# Altium schematic sheet TriangleGenerator.SchDoc(TriangleGenerator)
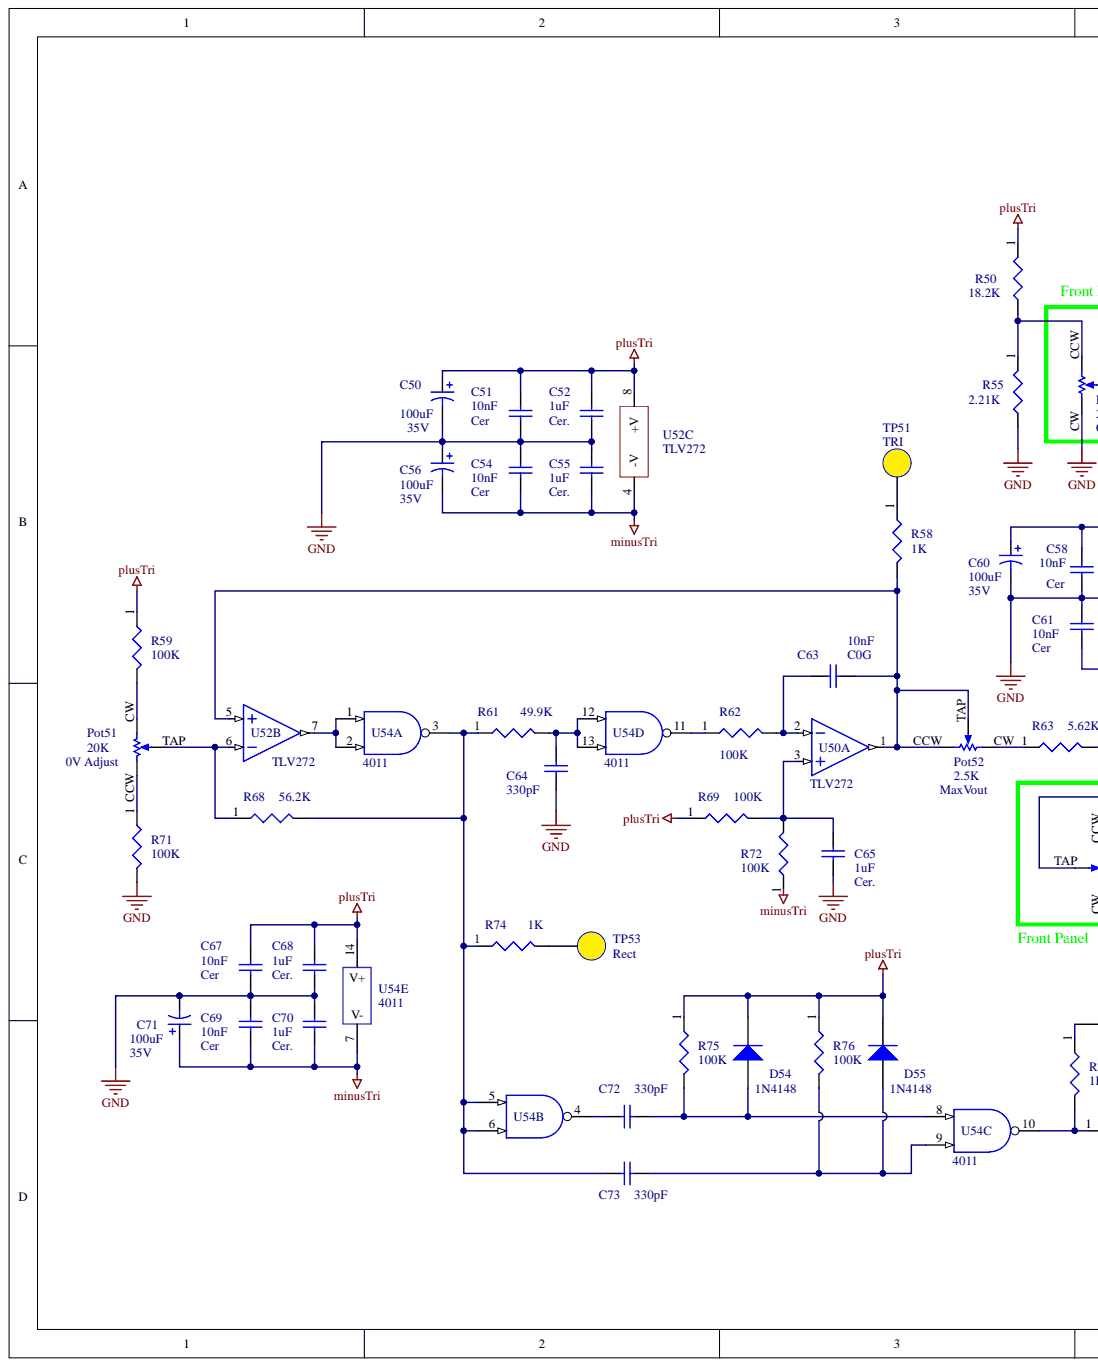
[diagram p0: TriangleGenerator.SchDoc, left]
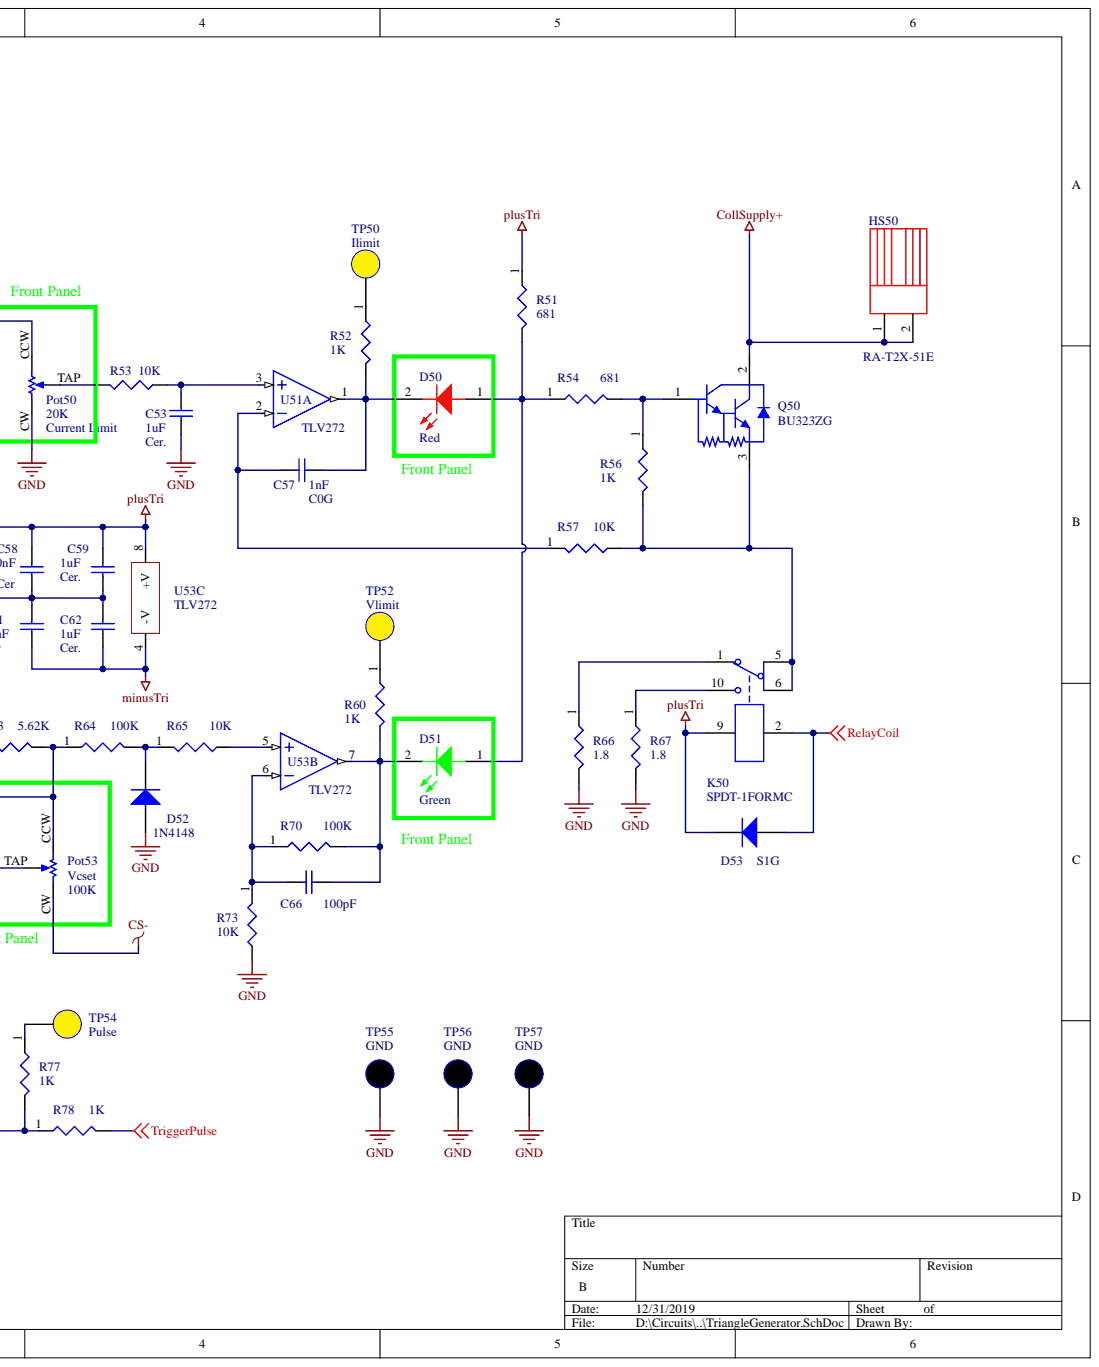
[diagram p1: TriangleGenerator.SchDoc, right]

## Parts
C50  100uF  Voltage Rating: 35V  [CAPPR2.5-6.3X10]
C51  10nF  Dielectric Material: Cer  [CAPC3216M]
C52  value 1uF  Dielectric Material: Cer.  [CAPC3216M]  (hidden comment: Cap)
C53  value 1uF  Dielectric Material: Cer.  [CAPC3216M]  (hidden comment: Cap)
C54  10nF  Dielectric Material: Cer  [CAPC3216M]
C55  value 1uF  Dielectric Material: Cer.  [CAPC3216M]  (hidden comment: Cap)
C56  100uF  Voltage Rating: 35V  [CAPPR2.5-6.3X10]
C57  value 1nF  Temperature Coefficient: C0G  [CAPC3216M]  (hidden comment: Cap)
C58  10nF  Dielectric Material: Cer  [CAPC3216M]
C59  value 1uF  Dielectric Material: Cer.  [CAPC3216M]  (hidden comment: Cap)
C60  100uF  Voltage Rating: 35V  [CAPPR2.5-6.3X10]
C61  10nF  Dielectric Material: Cer  [CAPC3216M]
C62  value 1uF  Dielectric Material: Cer.  [CAPC3216M]  (hidden comment: Cap)
C63  value 10nF  Temperature Coefficient: C0G  [CAPC3216M]  (hidden comment: Cap)
C64  value 330pF  [CAPC3216M]  (hidden comment: Cap)
C65  value 1uF  Dielectric Material: Cer.  [CAPC3216M]  (hidden comment: Cap)
C66  value 100pF  [CAPC3216M]  (hidden comment: Cap)
C67  10nF  Dielectric Material: Cer  [CAPC3216M]
C68  value 1uF  Dielectric Material: Cer.  [CAPC3216M]  (hidden comment: Cap)
C69  10nF  Dielectric Material: Cer  [CAPC3216M]
C70  value 1uF  Dielectric Material: Cer.  [CAPC3216M]  (hidden comment: Cap)
C71  100uF  Voltage Rating: 35V  [CAPPR2.5-6.3X10]
C72  value 330pF  [CAPC3216M]  (hidden comment: Cap)
C73  value 330pF  [CAPC3216M]  (hidden comment: Cap)
D50  Red  [LED-RED-3MM]
D51  Green  [LED-GRN-3MM]
D52  1N4148  [SOD123]
D53  S1G  [SMA]
D54  1N4148  [SOD123]
D55  1N4148  [SOD123]
HS50  Manufacturer Part Number: RA-T2X-51E  [HEATSINKVERT]  (hidden comment: 2 Pin Heat Sink)
K50  SPDT-1FORMC  [1FORMC-OMR]
Pot50  Current Limit  value 20K  [Off-PCB]
Pot51  0V Adjust  value 20K  [3306P-CCW]
Pot52  MaxVout  value 2.5K  [3306P-CCW]
Pot53  Vcset  value 100K  [Off-PCB]
Q50  BU323ZG  [TO220AB-123]
R50  18.2K  [RESC3216M]
R51  681  [RESC3216M]
R52  1K  [RESC3216M]
R53  10K  [RESC3216M]
R54  681  [RESC3216M]
R55  value 2.21K  [RESC3216M]  (hidden comment: 2.21KK)
R56  1K  [RESC3216M]
R57  10K  [RESC3216M]
R58  1K  [RESC3216M]
R59  100k  [RESC3216M]
R60  1K  [RESC3216M]
R61  49.9K  [RESC3216M]
R62  100k  [RESC3216M]
R63  5.62K  [RESC3216M]
R64  100k  [RESC3216M]
R65  10K  [RESC3216M]
R66  value 1.8  [RESC6432BM]  (hidden comment: 1K)
R67  value 1.8  [RESC6432BM]  (hidden comment: 1K)
R68  56.2K  [RESC3216M]
R69  100k  [RESC3216M]
R70  100k  [RESC3216M]
R71  100k  [RESC3216M]
R72  100k  [RESC3216M]
R73  10K  [RESC3216M]
R74  1K  [RESC3216M]
R75  100k  [RESC3216M]
R76  100k  [RESC3216M]
R77  1K  [RESC3216M]
R78  1K  [RESC3216M]
TP50  Ilimit  [TP-.038]
TP51  TRI  [TP-.038]
TP52  Vlimit  [TP-.038]
TP53  Rect  [TP-.038]
TP54  Pulse  [TP-.038]
TP55  GND  [TP-.038]
TP56  GND  [TP-.038]
TP57  GND  [TP-.038]
U50  value TLV272  [SOIC127P600X168_HS-8N]  (hidden comment: TLV271)  (part A)
U51  value TLV272  [SOIC127P600X168_HS-8N]  (hidden comment: TLV271)  (part A)
U52  value TLV272  [SOIC127P600X168_HS-8N]  (hidden comment: TLV271)  (parts B,C)
U53  value TLV272  [SOIC127P600X168_HS-8N]  (hidden comment: TLV271)  (parts B,C)
U54  4011  [SOIC127P600X14-M]  (parts A,B,C,D,E)

## Nets
CollSupply+: HS50.1 HS50.2 Q50.2
CS-: Pot53.CW
GND: C50.2 C51.1 C52.1 C53.2 C54.2 C55.2 C56.1 C58.1 C59.1 C60.2 C61.2 C62.2 C64.1 C65.1 C67.1 C68.1 C69.2 C70.2 C71.2 D52.1 Pot50.CW R55.2 R66.2 R67.2 R71.2 R73.2 TP55.1 TP56.1 TP57.1
minusTri: C54.1 C55.1 C56.2 C61.1 C62.1 C69.1 C70.1 C71.1 R72.1 U52.4(-V) U53.4(-V) U54.7(V-)
plusTri: C50.1 C51.2 C52.2 C58.2 C59.2 C60.1 C67.2 C68.2 D53.2 D54.2 D55.2 K50.9 R50.1 R51.1 R59.1 R69.1 R75.1 R76.1 U52.8(+V) U53.8(+V) U54.14(V+)
RelayCoil: D53.1 K50.2
TriggerPulse: R78.2
NetC53_1: C53.1 R53.2 U51.3
NetC57_1: C57.1 D50.2 R52.2 U51.1
NetC57_2: C57.2 R57.1 U51.2
NetC63_1: C63.1 Pot52.CCW Pot52.TAP R58.2 U50.1 U52.5
NetC63_2: C63.2 R62.2 U50.2
NetC64_2: C64.2 R61.2 U54.12 U54.13
NetC65_2: C65.2 R69.2 R72.2 U50.3
NetC66_1: C66.1 R70.1 R73.1 U53.6
NetC66_2: C66.2 D51.2 R60.2 R70.2 U53.7
NetC72_1: C72.1 D54.1 R75.2 U54.8
NetC72_2: C72.2 U54.4
NetC73_1: C73.1 D55.1 R76.2 U54.9
NetC73_2: C73.2 R61.1 R68.2 R74.1 U54.3 U54.5 U54.6
NetD50_1: D50.1 D51.1 R51.2 R54.1
NetD52_2: D52.2 R64.2 R65.1
NetK50_1: K50.1 R66.1
NetK50_5: K50.5 K50.6 Q50.3 R56.2 R57.2
NetK50_10: K50.10 R67.1
NetPot50_CCW: Pot50.CCW R50.2 R55.1
NetPot50_TAP: Pot50.TAP R53.1
NetPot51_CCW: Pot51.CCW R71.1
NetPot51_CW: Pot51.CW R59.2
NetPot51_TAP: Pot51.TAP R68.1 U52.6
NetPot52_CW: Pot52.CW R63.1
NetPot53_CCW: Pot53.CCW Pot53.TAP R63.2 R64.1
NetQ50_1: Q50.1 R54.2 R56.1
NetR52_1: R52.1 TP50.1
NetR58_1: R58.1 TP51.1
NetR60_1: R60.1 TP52.1
NetR62_1: R62.1 U54.11
NetR65_2: R65.2 U53.5
NetR74_2: R74.2 TP53.1
NetR77_1: R77.1 TP54.1
NetR77_2: R77.2 R78.1 U54.10
NetU52_7: U52.7 U54.1 U54.2

## Library data of the parts
C50 C56 C60 C71 = Cap Pol1: CAP ALUM 100UF 20% 35V THRU HOLE; Manufacturer Part Number: 35YXJ100M6.3X11; Manufacturer: Rubycon; Ripple Current: 400mA; Polarity: Polar; Life (Hours): 7000Hour; Leakage Current: 3uA; Lead Diameter: 0.5mm; Impedance: 220mR; Voltage: 35V; Value: 100uF; Tolerance: 20%; Termination: Radial; Supplier Part Number: 1189-2260-ND; Supplier: Digi-Key; Simulation: CAP; Signal Integrity: Cap Pol; Radiation Hardening: No; Packaging: Bulk; Package Quantity: 3000; Mount: Through Hole; Min Operating Temperature: -40°C; Max Operating Temperature: 105°C; Library Name: Miscellaneous Devices.IntLib; Lead Pitch: 2.5mm; Height - Seated (Max): 0.492inch; Height: 11mm; Diameter: 6.3mm; Component Kind: Standard; Case/Package: Radial; Capacitance: 100uF
C51 C54 C58 C61 C67 C69 = Cap: CAP CER 1UF 25V X7R 1206; Manufacturer Part Number: C1206C103K3RACTU; Manufacturer: KEMET; Tolerance: 10%; Width: 1.6mm; Voltage Rating (DC): 25V; Voltage Rating: 25V; Value: 10nF; Thickness: 0.035inch; Termination: SMD/SMT; Supplier Part Number: 399-14644-1-ND; Supplier: Digi-Key; Simulation: CAP; Signal Integrity: Cap; Radiation Hardening: No; Packaging: Tape and Reel; Package Quantity: 4000; Mount: Surface Mount; Min Operating Temperature: -55°C; Max Operating Temperature: 125°C; Library Name: Miscellaneous Devices.IntLib; Length: 3.2mm; Height: 0.78mm; Dielectric: X7R; Depth: 1.6mm; Component Kind: Standard; Case Code (Metric): 3216; Case Code (Imperial): 1206; Case/Package: 1206; Capacitance: 10nF
C52 C53 C55 C59 C62 C65 C68 C70 = Cap: CAP CER 1UF 25V X7R 1206; Manufacturer Part Number: C1206C105M3RACTU; Manufacturer: Kemet; Tolerance: ±20%; Capacitance: 1µF; Voltage - Rated: 25V; Thickness (Max): 0.055" (1.40mm); Temperature Coefficient: X7R; Supplier Part Number: 399-8148-1-ND; Supplier: Digi-Key; Size / Dimension: 0.126" L x 0.063" W (3.20mm x 1.60mm); Simulation: CAP; Signal Integrity: Cap; RoHS: RoHS Compliant; Packaging: Cut Tape (CT); Package / Case: 1206 (3216 Metric); Operating Temperature: -55°C ~ 125°C; Mounting Type: Surface Mount, MLCC; Library Name: Miscellaneous Devices.IntLib; Component Kind: Standard; Category: Capacitors; Applications: General Purpose
C57 = Cap: CAP CER 1NF 100V NP0 1206; Manufacturer Part Number: C1206C102J5GACAUTO; Manufacturer: KEMET; Voltage Rating (DC): 50V; Tolerance: 5%; Dielectric Material: Ceramic; Width: 0.063inch; Voltage Rating: 50V; Voltage - Rated: 100V; Thickness (Max): 0.035" (0.88mm); Thickness: 0.035inch; Supplier Part Number: 399-7002-6-ND; Supplier: Digi-Key; Size / Dimension: 0.126" L x 0.063" W (3.20mm x 1.60mm); Simulation: CAP; Signal Integrity: Cap; RoHS: RoHS Compliant; REACH SVHC: No SVHC; Published: 8-Jun-2000; Packaging: Cut Tape; PackageReference: RAD-0.3; Package Quantity: 1; PackageDescription: Radial Cap, Thru-Hole; 2 Leads; 0.3 in Pin Spacing; Package / Case: 1206 (3216 Metric); Operating Temperature: -55°C ~ 125°C; Mounting Type: Surface Mount, MLCC; Mount: Surface Mount; Min Operating Temperature: -55°C; Max Operating Temperature: 125°C; Library Name: Miscellaneous Devices.IntLib; Length: 0.126inch; Lead Free: Lead Free; LatestRevisionNote: Re-released for DXP Platform.; LatestRevisionDate: 17-Jul-2002; Dielectric: C0G; Depth: 1.6mm; Component Kind: Standard; Category: Capacitors; Case Code (Metric): 3216; Case Code (Imperial): 1206; Case/Package: 1206; Capacitance: 1nF; Applications: General Purpose; Publisher: Altium Limited
C63 = Cap: CAP CER 10NF 50V C0G 1206; Manufacturer Part Number: C3216C0G1H103J060AA; Manufacturer: TDK Corporation; Tolerance: ±5%; Voltage - Rated: 50V; Thickness (Max): 0.028" (0.70mm); Supplier Part Number: 445-7688-1-ND; Supplier: Digi-Key; Size / Dimension: 0.126" L x 0.063" W (3.20mm x 1.60mm); Simulation: CAP; Signal Integrity: Cap; RoHS: RoHS Compliant; Packaging: Cut Tape (CT); Package / Case: 1206 (3216 Metric); Operating Temperature: -55°C ~ 125°C; Mounting Type: Surface Mount, MLCC; Library Name: Miscellaneous Devices.IntLib; Component Kind: Standard; Category: Capacitors; Capacitance: 10nF; Applications: General Purpose
C64 C72 C73 = Cap: CAP CER 470PF 100V NP0 1206; Manufacturer Part Number: C1206C331J5GACTU; Manufacturer: KEMET; Voltage Rating (DC): 50V; Tolerance: 5%; Temperature Coefficient: 30ppm/°C; Dielectric Material: Ceramic; Dielectric: C0G; Width: 0.063inch; Voltage Rating: 50V; Voltage - Rated: 50V; Voltage: 50V; Thickness (Max): 0.035" (0.88mm); Thickness: 0.035inch; Termination: SMD/SMT; Supplier Part Number: 399-8186-1-ND; Supplier: Digi-Key; Size / Dimension: 0.126" L x 0.063" W (3.20mm x 1.60mm); Simulation: CAP; Signal Integrity: Cap; RoHS: RoHS Compliant; REACH SVHC: No SVHC; Radiation Hardening: No; Published: 8-Jun-2000; Part Status: Active; Packaging: Tape and Reel; PackageReference: RAD-0.3; Package Quantity: 1; PackageDescription: Radial Cap, Thru-Hole; 2 Leads; 0.3 in Pin Spacing; Package / Case: 1206 (3216 Metric); Operating Temperature: -55°C ~ 125°C; Mounting Type: Surface Mount, MLCC; Mount: Surface Mount; Min Operating Temperature: -55°C; Max Operating Temperature: 125°C; Library Name: Miscellaneous Devices.IntLib; Length: 3.2mm; Lead Free: Lead Free; LatestRevisionNote: Re-released for DXP Platform.; LatestRevisionDate: 17-Jul-2002; Height: 0.78mm; Features: Low ESL; Depth: 1.6mm; Contact Material: Tin; Component Kind: Standard; Category: Capacitors; Case Code (Metric): 3216; Case Code (Imperial): 1206; Case/Package: 1206; Capacitance: 330pF; Applications: General Purpose; Publisher: Altium Limited
C66 = Cap: CAP CER 100PF 100V NP0 1206; Manufacturer Part Number: C1206C101J1GACTU; Manufacturer: Kemet; Tolerance: ±5%; Temperature Coefficient: C0G; Voltage - Rated: 100V; Thickness (Max): 0.035" (0.88mm); Supplier Part Number: 399-1206-1-ND; Supplier: Digi-Key; Size / Dimension: 0.126" L x 0.063" W (3.20mm x 1.60mm); Simulation: CAP; Signal Integrity: Cap; RoHS: RoHS Compliant; Packaging: Cut Tape (CT); Package / Case: 1206 (3216 Metric); Operating Temperature: -55°C ~ 125°C; Mounting Type: Surface Mount, MLCC; Library Name: Miscellaneous Devices.IntLib; Features: Low ESL; Component Kind: Standard; Category: Capacitors; Capacitance: 100pF; Applications: General Purpose
D52 D54 D55 = Diode 1N4148: DIODE GP 75V 150MA SOD123FL; Manufacturer Part Number: 1N4148WFL-G3-08; Manufacturer: Vishay Semiconductor Diodes Division; Voltage - Forward (Vf) (Max) @ If: 1.2V @ 100mA; Speed: Small Signal =< 200mA (Io), Any Speed; Reverse Recovery Time (trr): 4ns; Diode Type: Standard; Current - Reverse Leakage @ Vr: 5µA @ 75V; Voltage - DC Reverse (Vr) (Max): 75V; Supplier Part Number: 1N4148WFL-G3-08GICT-ND; Supplier Device Package: SOD-123FL; Supplier: Digi-Key; Simulation: 1N4148; Signal Integrity: 1N4148; RoHS: RoHS Compliant; Published: 8-Jun-2000; Packaging: Cut Tape (CT); PackageReference: DO-35; PackageDescription: Diode, Axial; Body 3.8 x 1.9 mm (LxDia. max), Lead Dia. 0.53 mm (max); Package / Case: SOD-123F; Operating Temperature - Junction: 150°C (Max); Mounting Type: Surface Mount; Library Name: Miscellaneous Devices.IntLib; LatestRevisionNote: Re-released for DXP Platform.; LatestRevisionDate: 17-Jul-2002; DatasheetVersion: 1997; Current - Average Rectified (Io): 150mA; ComponentLink2URL: http://www.fairchildsemi.com/ds/1N%2F1N4148.pdf; ComponentLink2Description: Datasheet; ComponentLink1URL: http://www.fairchildsemi.com/; ComponentLink1Description: Manufacturer Link; Component Kind: Standard; Code_JEDEC: DO-204-AH; Category: Discrete Semiconductor Products; Publisher: Altium Limited
D53 = Diode 1N4002: Diode Switching 400V 1A 2-Pin DO-214AC T/R; Manufacturer: ON Semiconductor / Fairchild; Reverse Recovery Time: 1.8us; Recovery Time: 1800ns; Power Dissipation: 1.4W; Polarity: Standard; Peak Reverse Current: 1uA; Peak Non-Repetitive Surge Current: 30A; Max Surge Current: 40A; Forward Voltage: 1.1V; Element Configuration: Single; Capacitance: 12pF; Width: 2.95mm; Weight: 0.106g; Voltage Rating (DC): 400V; Supplier Part Number: S1GFSCT-ND; Supplier: Digi-Key; Simulation: 1N4002; Signal Integrity: 1N4002; Reverse Voltage: 400V; REACH SVHC: No SVHC; Radiation Hardening: No; Packaging: Tape and Reel; Package Quantity: 1; Output Current: 1A; Number of Pins: 2; Mount: Surface Mount; Min Operating Temperature: -55°C; Max Reverse Voltage (DC): 400V; Max Repetitive Reverse Voltage (Vrrm): 400V; Max Operating Temperature: 150°C; Max Forward Surge Current (Ifsm): 30A; Manufacturer Part Number: S1G; Library Name: Miscellaneous Devices.IntLib; Length: 4.75mm; Lead Free: Lead Free; Height: 2.2mm; Forward Current: 1A; Current Rating: 1A; Contact Plating: Tin,Matte; ComponentLink2URL: http://www.fairchildsemi.com/ds/1N%2F1N4001.pdf; ComponentLink2Description: Datasheet; ComponentLink1URL: http://www.fairchildsemi.com/; ComponentLink1Description: Manufacturer Link; Component Kind: Standard; Case/Package: SMA; Average Rectified Current: 1A
HS50 = HeatSink: HEAT SINK TO-247; Manufacturer: Ohmite; Thermal Resistance @ Forced Air Flow (100 LFM): 1°C/W; Shape: Fins,Rectangular; Natural Thermal Resistance: 3.5°C/W; Width: 25mm; Weight: 51g; Type: Passive; Supplier Part Number: RA-T2X-51E-ND; Supplier: Digi-Key; REACH SVHC: Unknown; Packaging: Bulk; Package Quantity: 10; Mount: Bolt,PC Pin,Screw,Vertical; Material: Aluminium; Library Name: MY_HeatSink.SchLib; Length: 42mm; Height Off Base: 0.984inch; Height: 50.8mm; Component Kind: Standard; Color: Black; Case/Package: TO-220
K50 = SPDT-1FORMC: RELAY GEN PURPOSE SPDT 1A 125V; Manufacturer Part Number: G5V-1-DC12; Manufacturer: Omron; Voltage Rating (DC): 60V; Release Time: 5ms; Relay Type: General Purpose; Power Rating: 150mW; Coil Voltage (DC): 12V; Coil Type: Non-Latching; Coil Resistance: 960R; Coil Current: 12.5mA; Approvals: UL,CSA; Width: 7.5mm; Weight: 2g; Voltage Rating (AC): 125V; Type: Electromechanical; Throw Configuration: SPDT; Termination: PC Pin; Supplier Part Number: Z774-ND; Supplier: Digi-Key; REACH SVHC: No SVHC; Radiation Hardening: No; Power Consumption: 150mW; Packaging: Rail/Tube; Package Quantity: 500; Operate Time: 5ms; Number of Pins: 6; Mount: Through Hole,PCB; Min Operating Temperature: -40°C; Max Voltage Rating (DC): 60V; Max Voltage Rating (AC): 125V; Max Operating Temperature: 70°C; Max Current Rating: 1A; Library Name: My_Relays.SchLib; Length: 12.5mm; Lead Free: Lead Free; Height: 10mm; Depth: 7.5mm; Current Rating: 1A; Contact Material: Gold,Silver; Contact Current Rating: 1A; Component Kind: Standard; Coil Power: 150mW; Case/Package: DIP
Pot50-Pot53 = POT-Single: TRIMMER 10K OHM 0.2W TH; Manufacturer Part Number: 3306P-1-103; Manufacturer: Bourns; Voltage Rating (DC): 100V; Tolerance: 25%; Temperature Coefficient: 250ppm/°C; Resistance: 10kR; Power Rating: 200mW; Pitch: 2.5mm; Number of Turns: 1; Lead Diameter: 1mm; Width: 6.81mm; Weight: 360mg; Voltage Rating: 100V; Type: Potentiometer; Termination: Pin; Taper: Linear; Supplier Part Number: 3306P-103-ND; Supplier: Digi-Key; REACH SVHC: No SVHC; Radiation Hardening: No; Packaging: Bulk; Package Quantity: 200; Number of Terminals: 3; Mount: Through Hole,PCB; Min Operating Temperature: -25°C; Military Standard: Not; Max Power Dissipation: 200mW; Max Operating Temperature: 100°C; Length: 6.81mm; Lead Free: Lead Free; Height: 7.62mm; Diameter: 6.81mm; Depth: 8.1mm; Composition: Cermet; Component Kind: Standard; Colour: Blue; Color: Blue; Library Name: MY_POTS.SchLib
Q50 = NPN3: TRANS NPN DARL 350V 10A TO247; Manufacturer: ON Semiconductor; Voltage Rating (DC): 35V; Transition Frequency: 2MHz; Power Dissipation: 150W; Number of Elements: 1; hFE Min: 150; Emitter Base Voltage (VEBO): 6V; Element Configuration: Single; Collector Emitter Saturation Voltage: 1.6V; Width: 4.9mm; Value: BU323ZG; Supplier Part Number: BU323ZGOS-ND; Supplier: Digi-Key; Simulation: NPN3; Signal Integrity: NPN; REACH SVHC: No SVHC; Radiation Hardening: No; Polarity: NPN; Packaging: Rail/Tube; Package Quantity: 30; Number of Pins: 3; Min Operating Temperature: -65°C; Max Power Dissipation: 150W; Max Operating Temperature: 175°C; Max Collector Current: 10A; Manufacturer Part Number: BU323ZG; Library Name: My_Miscellaneous Devices.IntLib; Length: 15.2mm; Lead Free: Lead Free; Height: 16.2mm; Current Rating: 10A; Contact Plating: Tin,Matte; Component Kind: Standard; Collector Emitter Voltage (VCEO): 350V; Collector Emitter Breakdown Voltage: 350V; Case/Package: TO-247-3
R50 = Res3: RES 18.2K OHM 1% 1/4W 1206; Manufacturer Part Number: RMCF1206FT18K2; Manufacturer: Stackpole Electronics; Voltage Rating (DC): 200V; Temperature Coefficient: 100ppm/°C; Resistance: 18.2kR; Power Rating: 250mW; Width: 0.063inch; Voltage Rating: 200V; Value: 18.2K; Tolerance: 1%; Termination: SMD/SMT; Supplier Part Number: RMCF1206FT18K2CT-ND; Supplier: Digi-Key; Simulation: RESISTOR; Signal Integrity: Res; REACH SVHC: No SVHC; Radiation Hardening: No; Packaging: Tape and Reel; Package Quantity: 1; Number of Terminations: 2; Number of Pins: 2; Mount: Surface Mount; Min Operating Temperature: -55°C; Military Standard: Not; Max Power Dissipation: 250mW; Max Operating Temperature: 155°C; Library Name: Miscellaneous Devices.IntLib; Length: 3.2mm; Lead Free: Lead Free; Height: 0.028inch; Features: Automotive AEC-Q200; Depth: 1.6mm; Contact Plating: Matte,Tin; Composition: Thick Film; Component Kind: Standard; Case Code (Metric): 3216; Case Code (Imperial): 1206; Case/Package: 1206
R51 R54 = Res3: RES 681 OHM 1% 1/4W 1206; Manufacturer Part Number: RMCF1206FT681R; Manufacturer: Stackpole Electronics; Temperature Coefficient: 100ppm/°C; Resistance: 681R; Power Rating: 250mW; Width: 0.063inch; Value: 681; Tolerance: 1%; Supplier Part Number: RMCF1206FT681RCT-ND; Supplier: Digi-Key; Simulation: RESISTOR; Signal Integrity: Res; Radiation Hardening: No; Packaging: Tape and Reel; Number of Terminations: 2; Mount: Surface Mount; Min Operating Temperature: -55°C; Military Standard: Not; Max Power Dissipation: 250mW; Max Operating Temperature: 155°C; Library Name: Miscellaneous Devices.IntLib; Length: 0.126inch; Lead Free: Lead Free; Height: 0.028inch; Features: Automotive AEC-Q200; Depth: 1.6mm; Contact Plating: Matte,Tin; Composition: Thick Film; Component Kind: Standard; Case Code (Metric): 3216; Case Code (Imperial): 1206; Case/Package: 1206
R52 R56 R58 R60 R66 R67 R74 R77 R78 = Res3: RES 1K OHM 1% 1/4W 1206; Manufacturer Part Number: RMCF1206FT1K00; Manufacturer: Stackpole Electronics; Temperature Coefficient: 100ppm/°C; Resistance: 1kR; Power Rating: 250mW; Width: 0.063inch; Tolerance: 1%; Supplier Part Number: RMCF1206FT1K00CT-ND; Supplier: Arrow; Simulation: RESISTOR; Signal Integrity: Res; Radiation Hardening: No; Packaging: Tape and Reel; Number of Terminations: 2; Mount: Surface Mount; Min Operating Temperature: -55°C; Military Standard: Not; Max Power Dissipation: 250mW; Max Operating Temperature: 155°C; Library Name: Miscellaneous Devices.IntLib; Length: 3.2mm; Lead Free: Lead Free; Height: 0.028inch; Features: Automotive AEC-Q200; Depth: 1.6mm; Contact Plating: Matte,Tin; Composition: Thick Film; Component Kind: Standard; Case Code (Metric): 3216; Case Code (Imperial): 1206; Case/Package: 1206
  R52 R56 R58 R60 R74 R77 R78: Value: 1K
R53 R57 R65 R73 = Res3: RES 10K OHM 1% 1/4W 1206; Manufacturer Part Number: RMCF1206FT10K0; Manufacturer: Stackpole Electronics; Voltage Rating (DC): 200V; Temperature Coefficient: 100ppm/°C; Resistance: 10kR; Power Rating: 250mW; Width: 0.063inch; Voltage Rating: 200V; Value: 10K; Tolerance: 1%; Termination: SMD/SMT; Supplier Part Number: RMCF1206FT10K0CT-ND; Supplier: Digi-Key; Simulation: RESISTOR; Signal Integrity: Res; REACH SVHC: No SVHC; Radiation Hardening: No; Packaging: Tape and Reel; Package Quantity: 1; Number of Terminations: 2; Number of Pins: 2; Mount: Surface Mount; Min Operating Temperature: -55°C; Military Standard: Not; Max Power Dissipation: 250mW; Max Operating Temperature: 155°C; Library Name: Miscellaneous Devices.IntLib; Length: 3.2mm; Lead Free: Lead Free; Height: 0.028inch; Features: Automotive AEC-Q200; Depth: 1.6mm; Contact Plating: Matte,Tin; Composition: Thick Film; Component Kind: Standard; Case Code (Metric): 3216; Case Code (Imperial): 1206; Case/Package: 1206
R55 = Res3: RES 2.21K OHM 1% 1/4W 1206; Manufacturer Part Number: RMCF1206FT2K21; Manufacturer: Stackpole Electronics; Temperature Coefficient: 100ppm/°C; Resistance: 2.21kR; Power Rating: 250mW; Width: 0.063inch; Tolerance: 1%; Supplier Part Number: RMCF1206FT2K21CT-ND; Supplier: Digi-Key; Simulation: RESISTOR; Signal Integrity: Res; Radiation Hardening: No; Packaging: Tape and Reel; Package Quantity: 1; Number of Terminations: 2; Mount: Surface Mount; Min Operating Temperature: -55°C; Military Standard: Not; Max Power Dissipation: 250mW; Max Operating Temperature: 155°C; Library Name: Miscellaneous Devices.IntLib; Length: 0.126inch; Lead Free: Lead Free; Height: 0.028inch; Features: Automotive AEC-Q200; Depth: 1.6mm; Contact Plating: Matte,Tin; Composition: Thick Film; Component Kind: Standard; Case Code (Metric): 3216; Case Code (Imperial): 1206; Case/Package: 1206
R59 R62 R64 R69-R72 R75 R76 = Res3: RES 100K OHM 1% 1/4W 1206; Manufacturer Part Number: RMCF1206FT100K; Manufacturer: Stackpole Electronics; Temperature Coefficient: 100ppm/°C; Resistance: 100kR; Power Rating: 250mW; Width: 0.063inch; Value: 100K; Tolerance: 1%; Supplier Part Number: RMCF1206FT100KCT-ND; Supplier: Digi-Key; Simulation: RESISTOR; Signal Integrity: Res; Radiation Hardening: No; Packaging: Tape and Reel; Number of Terminations: 2; Mount: Surface Mount; Min Operating Temperature: -55°C; Military Standard: Not; Max Power Dissipation: 250mW; Max Operating Temperature: 155°C; Library Name: Miscellaneous Devices.IntLib; Length: 0.126inch; Lead Free: Lead Free; Height: 0.028inch; Features: Automotive AEC-Q200; Depth: 1.6mm; Contact Plating: Matte,Tin; Composition: Thick Film; Component Kind: Standard; Case Code (Metric): 3216; Case Code (Imperial): 1206; Case/Package: 1206
R61 = Res3: RES 49.9K OHM 1% 1/4W 1206; Manufacturer Part Number: RMCF1206FT49K9; Manufacturer: Stackpole Electronics; Temperature Coefficient: 100ppm/°C; Resistance: 49.9kR; Power Rating: 250mW; Width: 0.063inch; Value: 49.9K; Tolerance: 1%; Supplier Part Number: RMCF1206FT49K9CT-ND; Supplier: Digi-Key; Simulation: RESISTOR; Signal Integrity: Res; Radiation Hardening: No; Packaging: Tape and Reel; Number of Terminations: 2; Mount: Surface Mount; Min Operating Temperature: -55°C; Military Standard: Not; Max Power Dissipation: 250mW; Max Operating Temperature: 155°C; Library Name: Miscellaneous Devices.IntLib; Length: 0.126inch; Lead Free: Lead Free; Height: 0.028inch; Features: Automotive AEC-Q200; Depth: 1.6mm; Contact Plating: Matte,Tin; Composition: Thick Film; Component Kind: Standard; Case Code (Metric): 3216; Case Code (Imperial): 1206; Case/Package: 1206
R63 = Res3: RES 5.62K OHM 1% 1/4W 1206; Manufacturer Part Number: RMCF1206FT5K62; Manufacturer: Stackpole Electronics; Temperature Coefficient: 100ppm/°C; Resistance: 5.62kR; Power Rating: 250mW; Width: 0.063inch; Value: 5.62K; Tolerance: 1%; Supplier Part Number: RMCF1206F5K62CT-ND; Supplier: Digi-Key; Simulation: RESISTOR; Signal Integrity: Res; Radiation Hardening: No; Packaging: Tape and Reel; Package Quantity: 1; Number of Terminations: 2; Mount: Surface Mount; Min Operating Temperature: -55°C; Military Standard: Not; Max Power Dissipation: 250mW; Max Operating Temperature: 155°C; Library Name: Miscellaneous Devices.IntLib; Length: 0.126inch; Lead Free: Lead Free; Height: 0.028inch; Features: Automotive AEC-Q200; Depth: 1.6mm; Contact Plating: Tin,Matte; Composition: Thick Film; Component Kind: Standard; Case Code (Metric): 3216; Case Code (Imperial): 1206; Case/Package: 1206
R68 = Res3: RES 56.2K OHM 1% 1/4W 1206; Manufacturer Part Number: RMCF1206FT56K2; Manufacturer: Stackpole Electronics; Temperature Coefficient: 100ppm/°C; Resistance: 56.2kR; Power Rating: 250mW; Width: 0.063inch; Value: 56.2K; Tolerance: 1%; Supplier Part Number: RMCF1206F56K2CT-ND; Supplier: Digi-Key; Simulation: RESISTOR; Signal Integrity: Res; Radiation Hardening: No; Packaging: Tape and Reel; Package Quantity: 1; Number of Terminations: 2; Mount: Surface Mount; Min Operating Temperature: -55°C; Military Standard: Not; Max Power Dissipation: 250mW; Max Operating Temperature: 155°C; Library Name: Miscellaneous Devices.IntLib; Length: 0.126inch; Lead Free: Lead Free; Height: 0.028inch; Features: Automotive AEC-Q200; Depth: 1.6mm; Contact Plating: Tin,Matte; Composition: Thick Film; Component Kind: Standard; Case Code (Metric): 3216; Case Code (Imperial): 1206; Case/Package: 1206
TP50-TP54 = TP-Round-Yellow: Library Name: MY_TP.SchLib; Component Kind: Standard
TP55-TP57 = TP-Round-Black: Library Name: MY_TP.SchLib; Component Kind: Standard
U50-U53 = Dual OP Amp separte supplies: IC OPAMP GP 3MHZ RRO 8SOIC; Manufacturer Part Number: TLV272IDR; Manufacturer: Texas Instruments; Voltage Gain: 110dB; Slew Rate: 2.1V/µs; Power Supply Rejection Ratio (PSRR): 70dB; Power Dissipation: 396mW; Output Current per Channel: 13mA; Operating Supply Voltage: 5V; Operating Supply Current: 625uA; Number of Elements: 2; Nominal Supply Current: 1.6mA; Nominal Gain Bandwidth Product: 2.4MHz; Min Supply Voltage: 2.7V; Min Dual Supply Voltage: 1.35V; Max Supply Voltage: 16V; Max I/O Voltage: 5mV; Max Dual Supply Voltage: 8V; Input Bias Current: 60pA; Common Mode Rejection Ratio: 58dB; Width: 3.91mm; Unity Gain Bandwidth Product: 3MHz; Supplier Part Number: 296-10655-1-ND; Supplier: Digi-Key; REACH SVHC: No SVHC; Radiation Hardening: No; Packaging: Tape and Reel; Package Quantity: 2500; Output Current: 13mA; Number of Pins: 8; Number of Circuits: 2; Number of Channels: 2; Number of Amplifiers: 2; Min Operating Temperature: -40°C; Max Power Dissipation: 396mW; Max Operating Temperature: 125°C; Library Name: My_OpAmps.SchLib; Length: 4.9mm; Lead Free: Lead Free; Input Offset Voltage (Vos): 5mV; Height: 1.58mm; Gain Bandwidth Product: 3MHz; Dual Supply Voltage: 5V; Contact Plating: Gold; Component Kind: Standard; Case/Package: D; Bandwidth: 3MHz
U54 = HCF4011BM1: ON SEMICONDUCTOR - MC14011BDR2G - QUAD NAND GATE, 2I/P, SOIC-14; Turn-On Delay Time: 80ns; Schmitt Trigger Input: No; Quiescent Current: 1uA; Output Type: TTL; Output Current: 8.8mA; Number of Outputs: 1; Number of Inputs: 2; Number of Gates: 4; Min Supply Voltage: 3V; Max Supply Voltage: 18V; Low Level Output Current: 4.2mA; High Level Output Current: -4.2mA; Width: 4mm; Supplier Part Number: MC14011BDR2GOSCT-ND; Supplier: Digi-Key; REACH SVHC: No SVHC; Radiation Hardening: No; Propagation Delay: 80ns; Packaging: Tape and Reel; Package Quantity: 1; Number of Pins: 14; Number of Elements: 4; Number of Circuits: 4; Number of Bits: 2; Mount: Surface Mount; Min Operating Temperature: -55°C; Max Operating Temperature: 125°C; Manufacturer Part Number: MC14011BDR2G; Manufacturer: ON Semiconductor; Logic Function: NAND; Library Name: MY_Logic Gate.SchLib; Length: 8.75mm; Lead Free: Lead Free; Height: 1.5mm; Halogen Free: Halogen Free; Contact Plating: Tin,Matte; Component Kind: Standard; Case/Package: SOIC
D50 = Red: Library Name: My_LED.SchLib; Component Kind: Standard
D51 = Green: Library Name: My_LED.SchLib; Component Kind: Standard
